# Altium schematic sheet Swich_PSU.SchDoc(Swich_PSU) (continued)
## Library data of the parts (continued)
R25 = RES1: Resistor 1%; Manufacturer: Panasonic; Manufacturer Part Number: ERJ-8ENF5600V; Part Description: RES 560R 1% 1206 250mW; LHADescription: Res Thick Film 1206 560 Ohm 1% 1/4W ±100ppm/°C Molded SMD Punched Carrier T/R; Max Power Dissipation: 250mW; Temperature Coefficient: 100ppm/°C; Voltage Rating: 200V; Case Code (Imperial): 1206; Case Code (Metric): 3216; Category: Resistors; Component Kind: Standard; ComponentLink1Description: http://www.yageo.com/documents/recent/PYu-RC_Group_51_RoHS_L_7.pdf; ComponentLink1URL: http://www.yageo.com/documents/recent/PYu-RC_Group_51_RoHS_L_7.pdf; ComponentLink2Description: https://datasheet.ciiva.com/11819/0900766b80c6630a-11819943.pdf?src-supplier=RSComponents; ComponentLink2URL: https://datasheet.ciiva.com/11819/0900766b80c6630a-11819943.pdf?src-supplier=RSComponents; ComponentLink3Description: https://datasheet.ciiva.com/11808/0900766b8079c86f-11808976.pdf?src-supplier=RSComponents; ComponentLink3URL: https://datasheet.ciiva.com/11808/0900766b8079c86f-11808976.pdf?src-supplier=RSComponents; ComponentLink4Description: https://datasheet.ciiva.com/12809/pyu-rt-1-to-0-05-rohs-l-4-12809879.pdf?src-supplier=Mouser; ComponentLink4URL: https://datasheet.ciiva.com/12809/pyu-rt-1-to-0-05-rohs-l-4-12809879.pdf?src-supplier=Mouser; ComponentLink5Description: https://datasheet.ciiva.com/2566/pyu-rt-1-to-0-05-rohs-l-5-2566812.pdf?src-supplier=Digi-Key; ComponentLink5URL: https://datasheet.ciiva.com/2566/pyu-rt-1-to-0-05-rohs-l-5-2566812.pdf?src-supplier=Digi-Key; ComponentLink6Description: https://datasheet.ciiva.com/3882/41790015s9557575n1386-3882896.pdf?src-supplier=Arrow+Electronics; ComponentLink6URL: https://datasheet.ciiva.com/3882/41790015s9557575n1386-3882896.pdf?src-supplier=Arrow+Electronics; ComponentLink7Description: https://datasheet.ciiva.com/17210/aoa0000ce1-17210213.pdf?src-supplier=Avnet+Europe; ComponentLink7URL: https://datasheet.ciiva.com/17210/aoa0000ce1-17210213.pdf?src-supplier=Avnet+Europe; ComponentLink8Description: https://datasheet.ciiva.com/9116/aoa0000ce1-9116742.pdf?src-supplier=Avnet; ComponentLink8URL: https://datasheet.ciiva.com/9116/aoa0000ce1-9116742.pdf?src-supplier=Avnet; ComponentLink9Description: https://datasheet.ciiva.com/16760/70260739-16760297.pdf?src-supplier=Allied+Electronics; ComponentLink9URL: https://datasheet.ciiva.com/16760/70260739-16760297.pdf?src-supplier=Allied+Electronics; ComponentLink10Description: https://datasheet.ciiva.com/46380/1678796-46380682.pdf?src-supplier=Element14; ComponentLink10URL: https://datasheet.ciiva.com/46380/1678796-46380682.pdf?src-supplier=Element14; ComponentLink11Description: https://datasheet.ciiva.com/30211/4aoa0000ce1-30211803.pdf?src-supplier=Onlinecomponents.com; ComponentLink11URL: https://datasheet.ciiva.com/30211/4aoa0000ce1-30211803.pdf?src-supplier=Onlinecomponents.com; ComponentLink12Description: https://datasheet.ciiva.com/43469/1678796-43469835.pdf?src-supplier=Farnell; ComponentLink12URL: https://datasheet.ciiva.com/43469/1678796-43469835.pdf?src-supplier=Farnell; ComponentLink13Description: https://datasheet.ciiva.com/16781/70260739-16781230.pdf?src-supplier=Allied+Electronics; ComponentLink13URL: https://datasheet.ciiva.com/16781/70260739-16781230.pdf?src-supplier=Allied+Electronics; ComponentLink14Description: https://datasheet.ciiva.com/8081/aoa0000ce1-8081279.pdf?src-supplier=Element14; ComponentLink14URL: https://datasheet.ciiva.com/8081/aoa0000ce1-8081279.pdf?src-supplier=Element14; ComponentLink15Description: https://datasheet.ciiva.com/9190/aoa0000ce2-9190613.pdf?src-supplier=Avnet; ComponentLink15URL: https://datasheet.ciiva.com/9190/aoa0000ce2-9190613.pdf?src-supplier=Avnet; ComponentLink16Description: https://datasheet.ciiva.com/22673/1678782-22673303.pdf?src-supplier=Element14; ComponentLink16URL: https://datasheet.ciiva.com/22673/1678782-22673303.pdf?src-supplier=Element14; ComponentLink17Description: https://datasheet.ciiva.com/5917/426256-5917896.pdf?src-supplier=Farnell; ComponentLink17URL: https://datasheet.ciiva.com/5917/426256-5917896.pdf?src-supplier=Farnell; ComponentLink18Description: https://datasheet.ciiva.com/16780/70260748-16780440.pdf?src-supplier=Allied+Electronics; ComponentLink18URL: https://datasheet.ciiva.com/16780/70260748-16780440.pdf?src-supplier=Allied+Electronics; ComponentLink19Description: https://datasheet.ciiva.com/22687/1678782-22687401.pdf?src-supplier=Element14; ComponentLink19URL: https://datasheet.ciiva.com/22687/1678782-22687401.pdf?src-supplier=Element14; ComponentLink20Description: https://datasheet.ciiva.com/30174/aoa0000ce2-30174747.pdf?src-supplier=Onlinecomponents.com; ComponentLink20URL: https://datasheet.ciiva.com/30174/aoa0000ce2-30174747.pdf?src-supplier=Onlinecomponents.com; ComponentLink21Description: https://datasheet.ciiva.com/16770/70260748-16770908.pdf?src-supplier=Allied+Electronics; ComponentLink21URL: https://datasheet.ciiva.com/16770/70260748-16770908.pdf?src-supplier=Allied+Electronics; ComponentLink22Description: https://datasheet.ciiva.com/7836/426256-7836462.pdf?src-supplier=Element14; ComponentLink22URL: https://datasheet.ciiva.com/7836/426256-7836462.pdf?src-supplier=Element14; ComponentLink23Description: https://datasheet.ciiva.com/24124/aoa0000ce2-24124255.pdf?src-supplier=Mouser; ComponentLink23URL: https://datasheet.ciiva.com/24124/aoa0000ce2-24124255.pdf?src-supplier=Mouser; ComponentLink24Description: https://datasheet.ciiva.com/46420/1678782-46420175.pdf?src-supplier=Element14; ComponentLink24URL: https://datasheet.ciiva.com/46420/1678782-46420175.pdf?src-supplier=Element14; ComponentLink25Description: https://datasheet.ciiva.com/5860/426256-5860729.pdf?src-supplier=Farnell; ComponentLink25URL: https://datasheet.ciiva.com/5860/426256-5860729.pdf?src-supplier=Farnell; ComponentLink26Description: https://datasheet.ciiva.com/7909/426256-7909270.pdf?src-supplier=Element14; ComponentLink26URL: https://datasheet.ciiva.com/7909/426256-7909270.pdf?src-supplier=Element14; ComponentLink27Description: https://datasheet.ciiva.com/22674/1678782-22674441.pdf?src-supplier=Element14; ComponentLink27URL: https://datasheet.ciiva.com/22674/1678782-22674441.pdf?src-supplier=Element14; ComponentLink28Description: https://datasheet.ciiva.com/46445/1678782-46445806.pdf?src-supplier=Element14; ComponentLink28URL: https://datasheet.ciiva.com/46445/1678782-46445806.pdf?src-supplier=Element14; ComponentLink29Description: https://datasheet.ciiva.com/22687/1678782-22687403.pdf?src-supplier=Element14; ComponentLink29URL: https://datasheet.ciiva.com/22687/1678782-22687403.pdf?src-supplier=Element14; ComponentLink30Description: https://datasheet.ciiva.com/22737/426256-22737582.pdf?src-supplier=Element14; ComponentLink30URL: https://datasheet.ciiva.com/22737/426256-22737582.pdf?src-supplier=Element14; ComponentLink31Description: https://datasheet.ciiva.com/46445/1678782-46445805.pdf?src-supplier=Element14; ComponentLink31URL: https://datasheet.ciiva.com/46445/1678782-46445805.pdf?src-supplier=Element14; ComponentLink32Description: https://datasheet.ciiva.com/24025/aoa0000ce2-24025980.pdf?src-supplier=Mouser; ComponentLink32URL: https://datasheet.ciiva.com/24025/aoa0000ce2-24025980.pdf?src-supplier=Mouser; ComponentLink33Description: https://datasheet.ciiva.com/9181/aoa0000ce2-9181178.pdf?src-supplier=Avnet; ComponentLink33URL: https://datasheet.ciiva.com/9181/aoa0000ce2-9181178.pdf?src-supplier=Avnet; ComponentLink34Description: https://datasheet.ciiva.com/15159/getdatasheetpartid-32666-15159303.pdf?src-supplier=Verical; ComponentLink34URL: https://datasheet.ciiva.com/15159/getdatasheetpartid-32666-15159303.pdf?src-supplier=Verical; Construction: Rectangular; Contact Plating: Tin; Depth: 1.6mm; Features: Automotive AEC-Q200; Height: 0.028inch; Length: 3.2mm; Library Name: LHA_LIB.SchLib; Max Operating Temperature: 155°C; Military Standard: Not; Min Operating Temperature: -55°C; Mount: Surface Mount; Number of Pins: 2; Number of Terminations: 2; Package Quantity: 1; PackageCase: 1206; Packaging: Tape and Reel; Power Rating: 250mW; Pricing 1: 1=0.18000, 10=0.15400, 100=0.06030, 1000=0.02522, 2500=0.02312 (USD); Radiation Hardening: No; REACH SVHC: No SVHC; Resistance: 560R; Resistance Tolerance: 1%; Resistor Type: Thick Film; RoHS: Yes; RoHS Compliant: Yes; Series: ERJ; Stock 1: 76026; Supplier 1: Digi-Key; Supplier Part Number 1: P560FCT-ND; Tolerance: 1%; Width: 0.063inch
R26 R27 = RES1: Resistor 1%; Manufacturer: Vishay; Manufacturer Part Number: CRCW120612K0FKEA; Part Description: RES 12kR 1% 1206 250mW; LHADescription: SMD Resistor, Thick film 12 kOhm,  ±  1 %, 1206, CRCW120612K0FKEA, Vishay; Max Power Dissipation: 250mW; Temperature Coefficient: 100ppm/°C; Voltage Rated: 200V; Case Code (Imperial): 1206; Case Code (Metric): 3216; Category: Resistors; Component Kind: Standard; ComponentLink1Description: http://www.yageo.com/documents/recent/PYu-RC_Group_51_RoHS_L_7.pdf; ComponentLink1URL: http://www.yageo.com/documents/recent/PYu-RC_Group_51_RoHS_L_7.pdf; ComponentLink2Description: https://datasheet.ciiva.com/11819/0900766b80c6630a-11819943.pdf?src-supplier=RSComponents; ComponentLink2URL: https://datasheet.ciiva.com/11819/0900766b80c6630a-11819943.pdf?src-supplier=RSComponents; ComponentLink3Description: https://datasheet.ciiva.com/11808/0900766b8079c86f-11808976.pdf?src-supplier=RSComponents; ComponentLink3URL: https://datasheet.ciiva.com/11808/0900766b8079c86f-11808976.pdf?src-supplier=RSComponents; ComponentLink4Description: https://datasheet.ciiva.com/12809/pyu-rt-1-to-0-05-rohs-l-4-12809879.pdf?src-supplier=Mouser; ComponentLink4URL: https://datasheet.ciiva.com/12809/pyu-rt-1-to-0-05-rohs-l-4-12809879.pdf?src-supplier=Mouser; ComponentLink5Description: https://datasheet.ciiva.com/2566/pyu-rt-1-to-0-05-rohs-l-5-2566812.pdf?src-supplier=Digi-Key; ComponentLink5URL: https://datasheet.ciiva.com/2566/pyu-rt-1-to-0-05-rohs-l-5-2566812.pdf?src-supplier=Digi-Key; ComponentLink6Description: https://datasheet.ciiva.com/3882/41790015s9557575n1386-3882896.pdf?src-supplier=Arrow+Electronics; ComponentLink6URL: https://datasheet.ciiva.com/3882/41790015s9557575n1386-3882896.pdf?src-supplier=Arrow+Electronics; ComponentLink7Description: https://datasheet.ciiva.com/17210/aoa0000ce1-17210213.pdf?src-supplier=Avnet+Europe; ComponentLink7URL: https://datasheet.ciiva.com/17210/aoa0000ce1-17210213.pdf?src-supplier=Avnet+Europe; ComponentLink8Description: https://datasheet.ciiva.com/9116/aoa0000ce1-9116742.pdf?src-supplier=Avnet; ComponentLink8URL: https://datasheet.ciiva.com/9116/aoa0000ce1-9116742.pdf?src-supplier=Avnet; ComponentLink9Description: https://datasheet.ciiva.com/16760/70260739-16760297.pdf?src-supplier=Allied+Electronics; ComponentLink9URL: https://datasheet.ciiva.com/16760/70260739-16760297.pdf?src-supplier=Allied+Electronics; ComponentLink10Description: https://datasheet.ciiva.com/46380/1678796-46380682.pdf?src-supplier=Element14; ComponentLink10URL: https://datasheet.ciiva.com/46380/1678796-46380682.pdf?src-supplier=Element14; ComponentLink11Description: https://datasheet.ciiva.com/30211/4aoa0000ce1-30211803.pdf?src-supplier=Onlinecomponents.com; ComponentLink11URL: https://datasheet.ciiva.com/30211/4aoa0000ce1-30211803.pdf?src-supplier=Onlinecomponents.com; ComponentLink12Description: https://datasheet.ciiva.com/43469/1678796-43469835.pdf?src-supplier=Farnell; ComponentLink12URL: https://datasheet.ciiva.com/43469/1678796-43469835.pdf?src-supplier=Farnell; ComponentLink13Description: https://datasheet.ciiva.com/16781/70260739-16781230.pdf?src-supplier=Allied+Electronics; ComponentLink13URL: https://datasheet.ciiva.com/16781/70260739-16781230.pdf?src-supplier=Allied+Electronics; ComponentLink14Description: https://datasheet.ciiva.com/8081/aoa0000ce1-8081279.pdf?src-supplier=Element14; ComponentLink14URL: https://datasheet.ciiva.com/8081/aoa0000ce1-8081279.pdf?src-supplier=Element14; ComponentLink15Description: https://datasheet.ciiva.com/11810/0900766b80d98b5e-11810923.pdf?src-supplier=RSComponents; ComponentLink15URL: https://datasheet.ciiva.com/11810/0900766b80d98b5e-11810923.pdf?src-supplier=RSComponents; ComponentLink16Description: https://datasheet.ciiva.com/2526/dcrcwe3-2526613.pdf?src-supplier=Digi-Key; ComponentLink16URL: https://datasheet.ciiva.com/2526/dcrcwe3-2526613.pdf?src-supplier=Digi-Key; ComponentLink17Description: https://datasheet.ciiva.com/17188/dcrcwe3-17188885.pdf?src-supplier=Avnet+Europe; ComponentLink17URL: https://datasheet.ciiva.com/17188/dcrcwe3-17188885.pdf?src-supplier=Avnet+Europe; ComponentLink18Description: https://datasheet.ciiva.com/2526/dcrcwe3-2526614.pdf?src-supplier=Digi-Key; ComponentLink18URL: https://datasheet.ciiva.com/2526/dcrcwe3-2526614.pdf?src-supplier=Digi-Key; ComponentLink19Description: https://datasheet.ciiva.com/2526/dcrcwe3-2526615.pdf?src-supplier=Digi-Key; ComponentLink19URL: https://datasheet.ciiva.com/2526/dcrcwe3-2526615.pdf?src-supplier=Digi-Key; ComponentLink20Description: https://datasheet.ciiva.com/7841/1533075-7841864.pdf?src-supplier=Element14; ComponentLink20URL: https://datasheet.ciiva.com/7841/1533075-7841864.pdf?src-supplier=Element14; ComponentLink21Description: https://datasheet.ciiva.com/7841/1697337-7841707.pdf?src-supplier=Element14; ComponentLink21URL: https://datasheet.ciiva.com/7841/1697337-7841707.pdf?src-supplier=Element14; ComponentLink22Description: https://datasheet.ciiva.com/5562/dcrcwe3-109170-5562142.pdf?src-supplier=Mouser; ComponentLink22URL: https://datasheet.ciiva.com/5562/dcrcwe3-109170-5562142.pdf?src-supplier=Mouser; ComponentLink23Description: https://datasheet.ciiva.com/16391/dcrcwe3-16391342.pdf?src-supplier=Future+Electronics; ComponentLink23URL: https://datasheet.ciiva.com/16391/dcrcwe3-16391342.pdf?src-supplier=Future+Electronics; ComponentLink24Description: https://datasheet.ciiva.com/16786/70201119-16786255.pdf?src-supplier=Allied+Electronics; ComponentLink24URL: https://datasheet.ciiva.com/16786/70201119-16786255.pdf?src-supplier=Allied+Electronics; ComponentLink25Description: https://datasheet.ciiva.com/26316/fld-crcw-e3-data-en-26316463.pdf?src-supplier=Distrelec; ComponentLink25URL: https://datasheet.ciiva.com/26316/fld-crcw-e3-data-en-26316463.pdf?src-supplier=Distrelec; Contact Plating: Tin; Depth: 1.6mm; Features: Automotive AEC-Q200; Height: 0.55mm; Lead Free: Lead Free; Length: 3.2mm; Library Name: LHA_LIB.SchLib; Max Operating Temperature: 155°C; Military Standard: Not; Min Operating Temperature: -55°C; Number of Terminations: 2; Package Quantity: 10; PackageCase: 1206; Packaging: Tape and Reel; Power Rating: 250mW; Pricing 1: 5000=0.00904, 10000=0.00811, 25000=0.00745, 50000=0.00724 (USD); Radiation Hardening: No; REACH SVHC: Unknown; Resistance: 12kR; Resistor Type: Thick Film; RoHS: Yes; Stock 1: 5000; Supplier 1: Digi-Key; Supplier Part Number 1: 541-12.0KFTR-ND; Termination: SMD/SMT; Tolerance: 1%; Weight: 0.000353oz; Width: 0.063inch
T1 = EF32_36V2A_Trafotek: Manufacturer: Trafotek CC; Manufacturer Part Number: E32 26VZA; Capacitance: 0pF; Frequency: 200kHz; Inductance: 152uH(Leakage < 2.3uH); Isolation Voltage: 1.5kV; LHADescription: FLYBACK TRANSFORMER, 36V; Output Voltage: 36V; Turns Ratio: 29:4:9; Category: Transformers; Component Kind: Standard; ComponentLink1Description: https://datasheet.ciiva.com/1431/750310562-1431239.pdf?src-supplier=Newark; ComponentLink1URL: https://datasheet.ciiva.com/1431/750310562-1431239.pdf?src-supplier=Newark; ComponentLink2Description: https://datasheet.ciiva.com/1379/1736725-1379257.pdf?src-supplier=Element14; ComponentLink2URL: https://datasheet.ciiva.com/1379/1736725-1379257.pdf?src-supplier=Element14; ComponentLink3Description: https://datasheet.ciiva.com/1387/1736725-1387787.pdf?src-supplier=Farnell; ComponentLink3URL: https://datasheet.ciiva.com/1387/1736725-1387787.pdf?src-supplier=Farnell; Height - Seated (Max): 24mm; Length: 23mm; Library Name: LHA_LIB_Generic.SchLib; Max Operating Temperature: 125°C; Min Operating Temperature: -40°C; Mount: THL; Package Quantity: 1; PackageCase: THL; Packaging: Box; Part Description: FLYBACK TRANSFORMER, 36V; Pricing 1: 250=5.04004 (USD); REACH SVHC: No SVHC; RoHS: Yes; Width: 23mm
U1 = Optoisolator1: 4-Pin Phototransistor Optocoupler; Manufacturer: CEL; Current - DC Forward (If): 30mA; Current Transfer Ratio (Max): 400% @ 5mA; Current Transfer Ratio (Min): 50% @ 5mA; Input Type: DC; LHADescription: OPTOISOLATOR 5KV TRANS 4SMD; Number of Channels: 1; Rise / Fall Time (Typ): 3µs, 5µs; Vce Saturation (Max): 300mV; Voltage - Forward (Vf) (Typ): 1.2V; Voltage - Isolation: 5000Vrms; Voltage - Output: 70V; Category: Isolators; Component Kind: Standard; ComponentLink1Description: http://www.cel.com/pdf/datasheets/ps2561a.pdf; ComponentLink1URL: http://www.cel.com/pdf/datasheets/ps2561a.pdf; Current - DC Forward (If) (Max): 30mA; Current - Output / Channel: 30mA; Library Name: LHA_LIB.SchLib; Manufacturer Part Number: PS2561AL-1-F3-A; Mounting Type: Surface Mount; Operating Temperature: -55°C ~ 100°C; Output Type: Transistor; PackageCase: 4-SMD, Gull Wing; Packaging: Cut Tape (CT); Part Description: OPTOISOLATOR 5KV TRANS 4SMD; Part Status: Active; Pricing: 1=0.48, 10=0.4, 100=0.3, 250=0.26, 500=0.22, 1000=0.17 (USD); Pricing 1: 1=0.66, 10=0.515, 25=0.4292, 100=0.3696, 250=0.33, 500=0.2838, 1000=0.21912 (USD); RoHS: RoHS Compliant; Stock: 9698; Stock 1: 12824; Supplier 1: Digi-Key; Supplier Device Package: 4-SMD; Supplier Part Number 1: PS2561AL-1CT-ND; Turn On / Turn Off Time (Typ): -; Type: IC; Voltage - Output (Max): 70V
U2 = TOP268KG: IC OFFLINE SW 50W 73W 12ESOP; Manufacturer: Power Integrations; Breakdown Voltage: 725V; LHADescription: IC OFFLINE SW 60W 91W 12ESOP; Max Duty Cycle: 83%; Max Input Voltage: 265V; Max Power Dissipation: 60W; Min Input Voltage: 85V; Number of Outputs: 1; Output Current: 9A; Power Rating: 91W; Switching Frequency: 132kHz; Topology: Flyback; Category: Integrated Circuits (ICs); Component Kind: Standard; ComponentLink1Description: http://www.powerint.com/sites/default/files/product-docs/topjx_family_datasheet.pdf; ComponentLink1URL: http://www.powerint.com/sites/default/files/product-docs/topjx_family_datasheet.pdf; ComponentLink2Description: https://www.power.com/sites/default/files/product-docs/topjx_family_datasheet.pdf; ComponentLink2URL: https://www.power.com/sites/default/files/product-docs/topjx_family_datasheet.pdf; ComponentLink3Description: https://datasheet.ciiva.com/7649/1677432-7649566.pdf?src-supplier=Element14; ComponentLink3URL: https://datasheet.ciiva.com/7649/1677432-7649566.pdf?src-supplier=Element14; ComponentLink4Description: https://datasheet.ciiva.com/8157/topjx-family-datasheet-8157454.pdf?src-supplier=Avnet; ComponentLink4URL: https://datasheet.ciiva.com/8157/topjx-family-datasheet-8157454.pdf?src-supplier=Avnet; ComponentLink5Description: https://datasheet.ciiva.com/1929/topjx-family-datasheet-1929951.pdf?src-supplier=Digi-Key; ComponentLink5URL: https://datasheet.ciiva.com/1929/topjx-family-datasheet-1929951.pdf?src-supplier=Digi-Key; Library Name: LHA_LIB.SchLib; Manufacturer Part Number: TOP270KG; Max Input Voltage (AC): 265V; Max Operating Temperature: 150°C; Max Supply Voltage (AC): 265V; Min Input Voltage (AC): 85V; Min Operating Temperature: -40°C; Min Supply Voltage (AC): 85V; Number of Pins: 12; Output Power: 60W; Package Quantity: 48; PackageCase: SOP; Packaging: Rail/Tube; Part Description: IC OFFLINE SW 60W 91W 12ESOP; Pricing 1: 1=2.76000, 2500=1.93001 (USD); Radiation Hardening: No; REACH SVHC: No SVHC; RoHS: Yes; RoHS Compliant: Yes; Series: TOPSwitch®-JX; Stock 1: 3; Supplier 1: Digi-Key; Supplier Part Number 1: 596-1407-ND
U3 = MP2456: Step-Down Converter in a TSOT23-6; Manufacturer: Monolithic Power Systems Inc.; Current - Output: 500mA; Frequency - Switching: 1.2MHz; LHADescription: IC REG BUCK ADJ 0.5A; Number of Outputs: 1; Output Configuration: Positive; Output Type: Adjustable; Synchronous Rectifier: No; Topology: Buck; Voltage - Input (Max): 50V; Voltage - Input (Min): 4.5V; Voltage - Output (Max): 45V; Voltage - Output (Min/Fixed): 0.81V; Category: Integrated Circuits (ICs); Component Kind: Standard; ComponentLink1Description: http://www.monolithicpower.com/DesktopModules/DocumentManage/API/Document/getDocument?id=2929; ComponentLink1URL: http://www.monolithicpower.com/DesktopModules/DocumentManage/API/Document/getDocument?id=2929; Function: Step-Down; Library Name: LHA_LIB.SchLib; Manufacturer Part Number: MP2456GJ-Z; Mounting Type: Surface Mount; Operating Temperature: -40°C ~ 125°C (TJ); PackageCase: SOT-23-6 Thin, TSOT-23-6; Packaging: Tape & Reel (TR); Part Description: IC REG BUCK ADJ 0.5A; Part Status: Active; Pricing 1: 3000=0.574 (USD); RoHS: RoHS Compliant; Stock 1: 0; Supplier 1: Digi-Key; Supplier Device Package: TSOT-23-6; Supplier Part Number 1: MP2456GJ-Z-ND
U4 = CMP-0366-01122-2: Adjustable Precision Shunt Regulator, 34 ppm / degC, 100 mA, -40 to 125 degC, 3-pin SOT-23 (DBZ), Green (RoHS & no Sb/Br); Manufacturer: Texas Instruments; Cathode Current: 1mA; Initial Accuracy @ 25/deg C(%): 2; Iout/Iz(Max)(mA): 100; LHADescription: V-Ref Adjustable 2.495V to 36V 100mA 3-Pin TO-236AB T/R; Max Input Voltage: 37V; Max Output Current: 100mA; Max Output Voltage: 36V; Min Input Voltage: 2.483V; Min Iz for Regulation(uA): 600; Number of Channels: 1; Rating: Military; Reference Type: Shunt,Adjustable; SubFamily: Shunt Voltage Reference; Temp Coeff(Max)(ppm/ degree C): 92; Temp Coeff(Typ)(ppm/ degree C): 34; Temperature Coefficient: 92ppm/°C; Tolerance: 2%; VO Adj(Max)(V): 36; VO Adj(Min)(V): 2.495; Category: Voltage References; Code_JEDEC: TO-236; Component Kind: Standard; ComponentLink1Description: Manufacturer URL; ComponentLink1URL: http://www.ti.com/; ComponentLink2Description: Datasheet; ComponentLink2URL: http://www.ti.com/general/docs/lit/getliterature.tsp?genericPartNumber=TL431&fileType=pdf; ComponentLink3Description: Package Specification; ComponentLink3URL: http://www.ti.com/litv/pdf/mpds108a; ComponentLink4Description: https://datasheet.ciiva.com/46397/1887475-46397702.pdf?src-supplier=Element14; ComponentLink4URL: https://datasheet.ciiva.com/46397/1887475-46397702.pdf?src-supplier=Element14; ComponentLink5Description: https://datasheet.ciiva.com/2006/tl431a-2006273.pdf?src-supplier=Digi-Key; ComponentLink5URL: https://datasheet.ciiva.com/2006/tl431a-2006273.pdf?src-supplier=Digi-Key; ComponentLink6Description: https://datasheet.ciiva.com/2006/tl431a-2006272.pdf?src-supplier=Digi-Key; ComponentLink6URL: https://datasheet.ciiva.com/2006/tl431a-2006272.pdf?src-supplier=Digi-Key; ComponentLink7Description: https://datasheet.ciiva.com/2006/tl431a-2006274.pdf?src-supplier=Digi-Key; ComponentLink7URL: https://datasheet.ciiva.com/2006/tl431a-2006274.pdf?src-supplier=Digi-Key; ComponentLink8Description: https://datasheet.ciiva.com/14366/tl432b-14366058.pdf?src-supplier=Avnet; ComponentLink8URL: https://datasheet.ciiva.com/14366/tl432b-14366058.pdf?src-supplier=Avnet; DatasheetVersion: SLVS543M; Eco-Plan: Green (RoHS & no Sb/Br); Lead Free: Lead Free; Library Name: Altium Content Vault; Manufacturer Part Number: TL431QDBZR; Max Operating Temperature: 125°C; Min Operating Temperature: -40°C; Mounting Technology: Surface Mount; Number of Pins: 3; Output Current: 100mA; Output Voltage: 36V; Package Quantity: 1; PackageCase: SOT-23-3; PackageDescription: 3-Pin Plastic Small Outline, Body 2.92 x 1.3 mm, Pitch 0.95 mm; PackageReference: DBZ0003A; PackageVersion: 4203227/B; Packaging: Tape and Reel; Part Description: V-Ref Adjustable 2.495V to 36V 100mA 3-Pin TO-236AB T/R; PartNumber: TL431QDBZR; Pricing 1: 1=0.53000, 10=0.43300, 25=0.39640, 100=0.29510, 250=0.26744, 500=0.22132, 1000=0.16600 (USD); REACH SVHC: No SVHC; Reference Voltage: 36V; RoHS: Yes; RoHS Compliant: Yes; Series: -; Stock 1: 11365; Supplier 1: Digi-Key; Supplier Part Number 1: 296-17334-1-ND
